# Altium schematic sheet LIDO-HW.100.InputStage_1.SchDoc(LIDO-HW.100.InputStage_1)
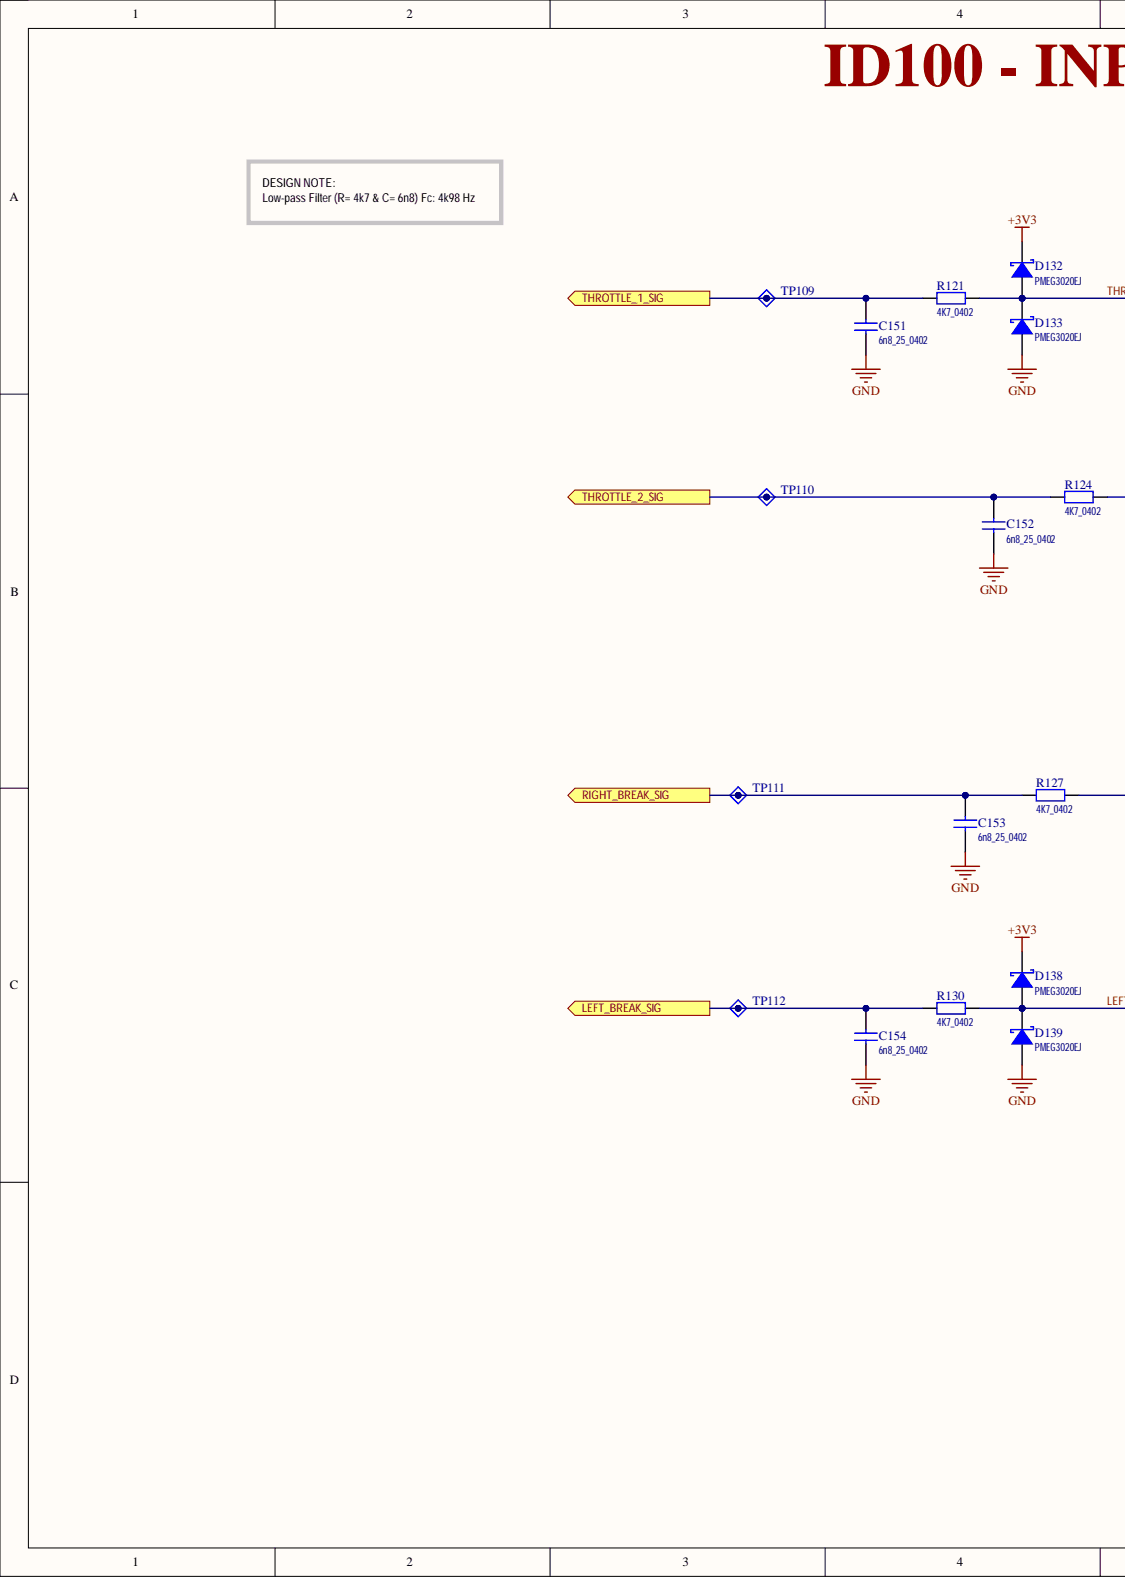
[diagram p0: LIDO-HW.100.InputStage_1.SchDoc, left]
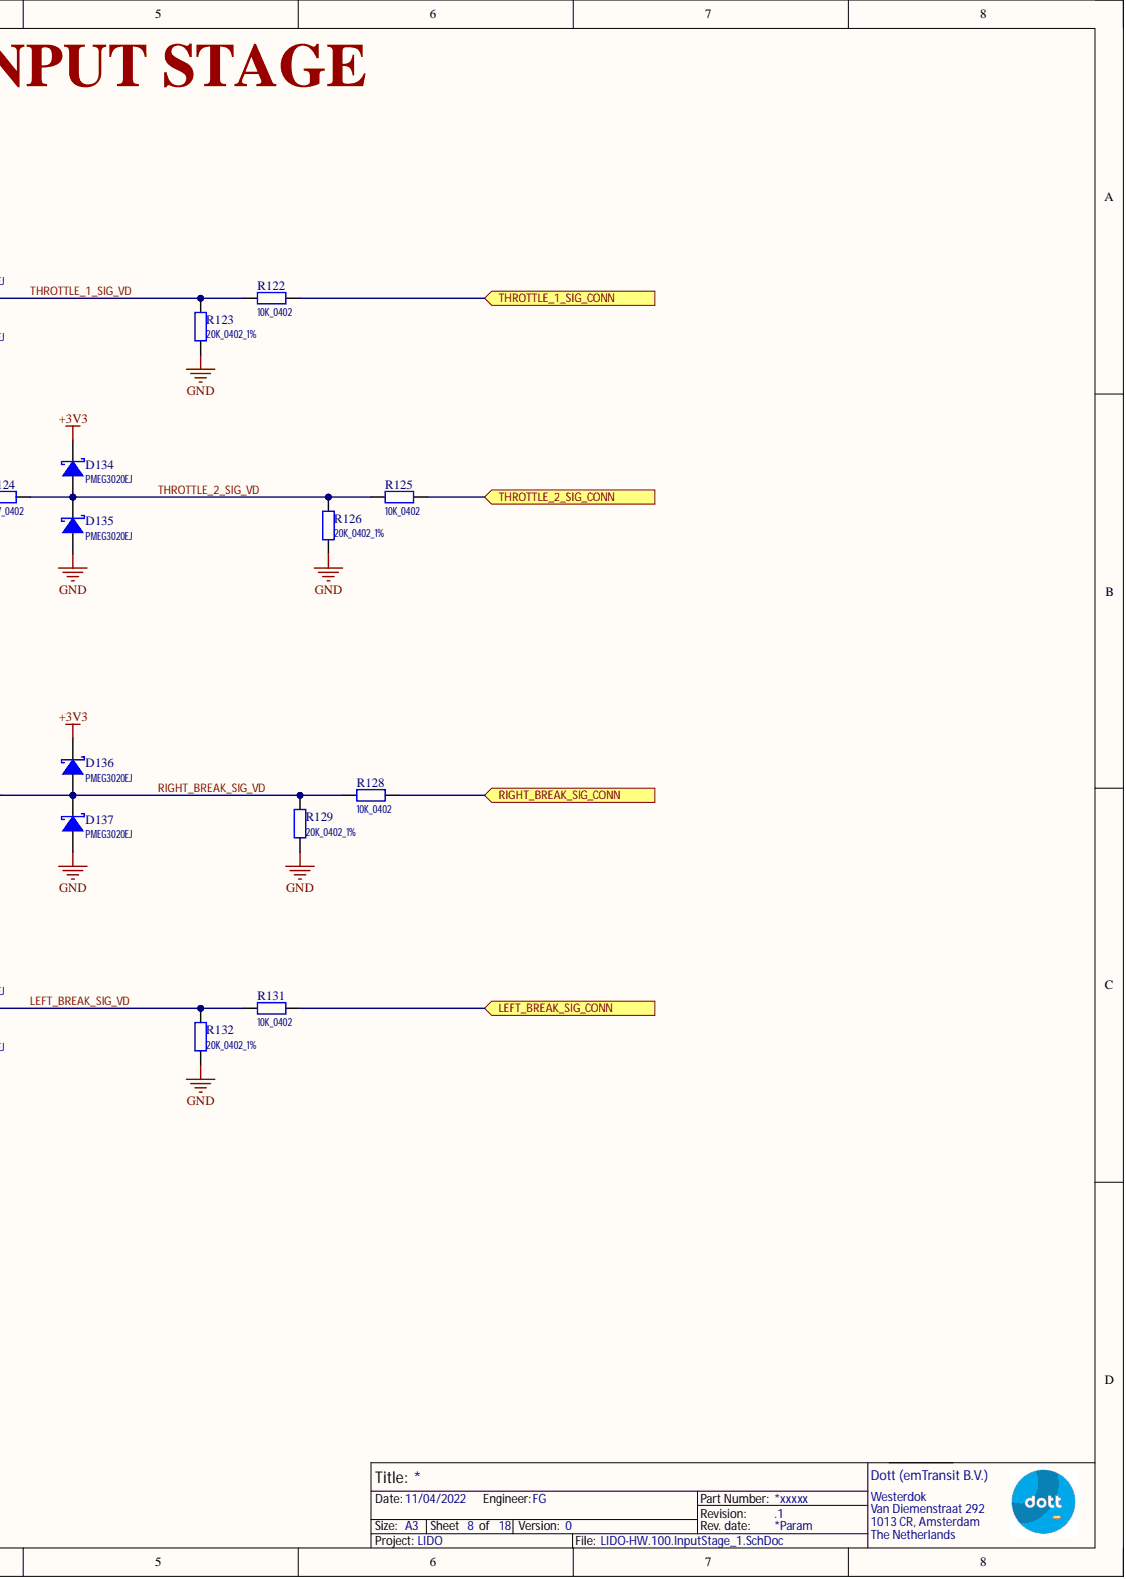
[diagram p1: LIDO-HW.100.InputStage_1.SchDoc, right]

## Parts
C151  6n8_25_0402
C152  6n8_25_0402
C153  6n8_25_0402
C154  6n8_25_0402
D132  PMEG3020EJ
D133  PMEG3020EJ
D134  PMEG3020EJ
D135  PMEG3020EJ
D136  PMEG3020EJ
D137  PMEG3020EJ
D138  PMEG3020EJ
D139  PMEG3020EJ
R121  4K7_0402
R122  10K_0402
R123  20K_0402_1%
R124  4K7_0402
R125  10K_0402
R126  20K_0402_1%
R127  4K7_0402
R128  10K_0402
R129  20K_0402_1%
R130  4K7_0402
R131  10K_0402
R132  20K_0402_1%
TP109  TestPoint_PWR  (value not drawn)  (no BOM)
TP110  TestPoint_PWR  (value not drawn)  (no BOM)
TP111  TestPoint_PWR  (value not drawn)  (no BOM)
TP112  TestPoint_PWR  (value not drawn)  (no BOM)

## Ports
LEFT_BREAK_SIG, LEFT_BREAK_SIG_CONN, RIGHT_BREAK_SIG, RIGHT_BREAK_SIG_CONN, THROTTLE_1_SIG, THROTTLE_1_SIG_CONN, THROTTLE_2_SIG, THROTTLE_2_SIG_CONN

## Nets
+3V3: D132.C D134.C D136.C D138.C
GND: C151.2 C152.2 C153.2 C154.2 D133.A D135.A D137.A D139.A R123.1 R126.1 R129.1 R132.1
LEFT_BREAK_SIG: C154.1 R130.1 TP112.1 ; port LEFT_BREAK_SIG
LEFT_BREAK_SIG_CONN: R131.2 ; port LEFT_BREAK_SIG_CONN
LEFT_BREAK_SIG_VD: D138.A D139.C R130.2 R131.1 R132.2
RIGHT_BREAK_SIG: C153.1 R127.1 TP111.1 ; port RIGHT_BREAK_SIG
RIGHT_BREAK_SIG_CONN: R128.2 ; port RIGHT_BREAK_SIG_CONN
RIGHT_BREAK_SIG_VD: D136.A D137.C R127.2 R128.1 R129.2
THROTTLE_1_SIG: C151.1 R121.1 TP109.1 ; port THROTTLE_1_SIG
THROTTLE_1_SIG_CONN: R122.2 ; port THROTTLE_1_SIG_CONN
THROTTLE_1_SIG_VD: D132.A D133.C R121.2 R122.1 R123.2
THROTTLE_2_SIG: C152.1 R124.1 TP110.1 ; port THROTTLE_2_SIG
THROTTLE_2_SIG_CONN: R125.2 ; port THROTTLE_2_SIG_CONN
THROTTLE_2_SIG_VD: D134.A D135.C R124.2 R125.1 R126.2

## Library data of the parts
C151-C154 = Ceramic: Ceramic CAP Symbol; Component Kind: Standard; Library Name: 01_Capacitors.SchLib
D132-D139 = Schottky_A: Schottky Diode Symbol; Component Kind: Standard; Library Name: 06_Diodes.SchLib
R121-R132 = Resistor: Resistor Symbol; Component Kind: Standard; Library Name: 02_Resistors.SchLib
TP109-TP112 = TestPoint_A: Test Point Symbol verion A; 00_Name: TP_XXmm; 01_Diameter: 1mm; Library Name: 31_MFG_Elements.SchLib
